# Altium schematic sheet 05_Outputs_LEDs.SchDoc(05_Outputs_LEDs)
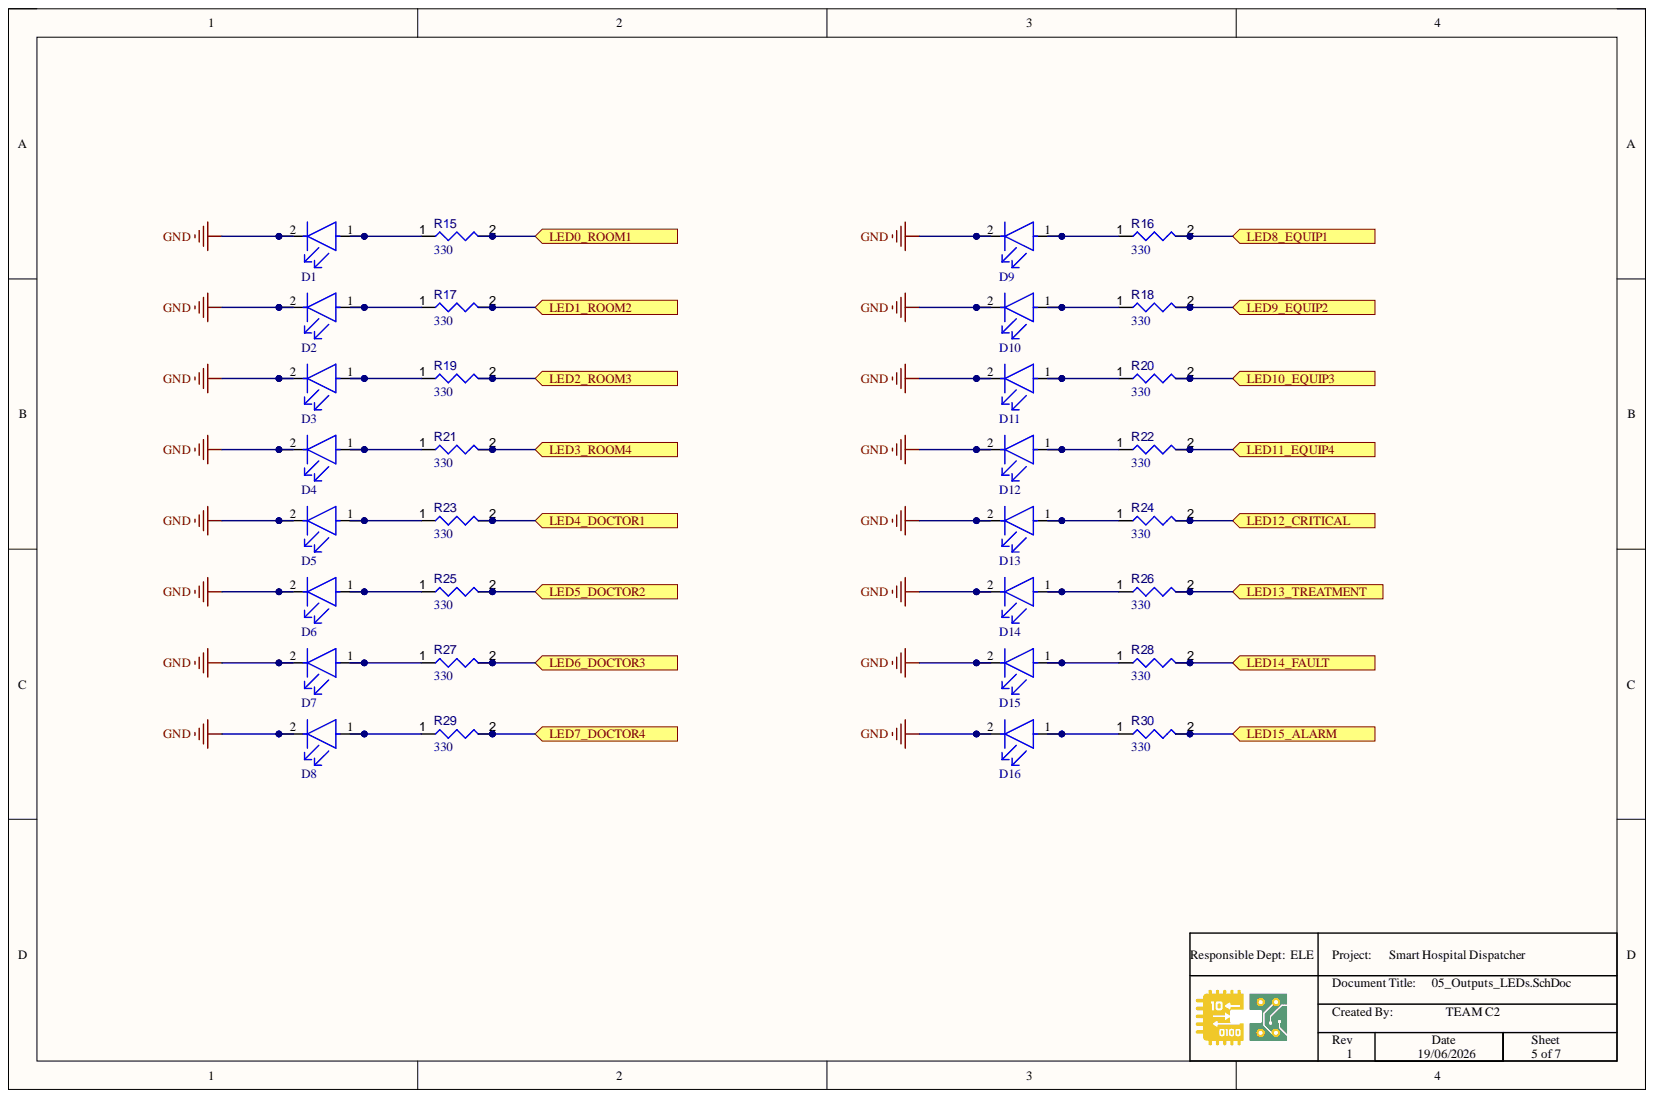
[diagram p0: 05_Outputs_LEDs.SchDoc]

## Parts
D1  SM0402GC  [FP-SM0402GC-MFG]  (value not drawn)
D2  SM0402GC  [FP-SM0402GC-MFG]  (value not drawn)
D3  SM0402GC  [FP-SM0402GC-MFG]  (value not drawn)
D4  SM0402GC  [FP-SM0402GC-MFG]  (value not drawn)
D5  SM0402GC  [FP-SM0402GC-MFG]  (value not drawn)
D6  SM0402GC  [FP-SM0402GC-MFG]  (value not drawn)
D7  SM0402GC  [FP-SM0402GC-MFG]  (value not drawn)
D8  SM0402GC  [FP-SM0402GC-MFG]  (value not drawn)
D9  SM0402GC  [FP-SM0402GC-MFG]  (value not drawn)
D10  SM0402GC  [FP-SM0402GC-MFG]  (value not drawn)
D11  SM0402GC  [FP-SM0402GC-MFG]  (value not drawn)
D12  SM0402GC  [FP-SM0402GC-MFG]  (value not drawn)
D13  SM0402GC  [FP-SM0402GC-MFG]  (value not drawn)
D14  SM0402GC  [FP-SM0402GC-MFG]  (value not drawn)
D15  SM0402GC  [FP-SM0402GC-MFG]  (value not drawn)
D16  SM0402GC  [FP-SM0402GC-MFG]  (value not drawn)
R15  value 330  [RESC0402(1005)_L]  (hidden comment: 330R2J)
R16  value 330  [RESC0402(1005)_L]  (hidden comment: 330R2J)
R17  value 330  [RESC0402(1005)_L]  (hidden comment: 330R2J)
R18  value 330  [RESC0402(1005)_L]  (hidden comment: 330R2J)
R19  value 330  [RESC0402(1005)_L]  (hidden comment: 330R2J)
R20  value 330  [RESC0402(1005)_L]  (hidden comment: 330R2J)
R21  value 330  [RESC0402(1005)_L]  (hidden comment: 330R2J)
R22  value 330  [RESC0402(1005)_L]  (hidden comment: 330R2J)
R23  value 330  [RESC0402(1005)_L]  (hidden comment: 330R2J)
R24  value 330  [RESC0402(1005)_L]  (hidden comment: 330R2J)
R25  value 330  [RESC0402(1005)_L]  (hidden comment: 330R2J)
R26  value 330  [RESC0402(1005)_L]  (hidden comment: 330R2J)
R27  value 330  [RESC0402(1005)_L]  (hidden comment: 330R2J)
R28  value 330  [RESC0402(1005)_L]  (hidden comment: 330R2J)
R29  value 330  [RESC0402(1005)_L]  (hidden comment: 330R2J)
R30  value 330  [RESC0402(1005)_L]  (hidden comment: 330R2J)

## Ports
LED0_ROOM1, LED1_ROOM2, LED2_ROOM3, LED3_ROOM4, LED4_DOCTOR1, LED5_DOCTOR2, LED6_DOCTOR3, LED7_DOCTOR4, LED8_EQUIP1, LED9_EQUIP2, LED10_EQUIP3, LED11_EQUIP4, LED12_CRITICAL, LED13_TREATMENT, LED14_FAULT, LED15_ALARM

## Nets
GND: D1.2 D2.2 D3.2 D4.2 D5.2 D6.2 D7.2 D8.2 D9.2 D10.2 D11.2 D12.2 D13.2 D14.2 D15.2 D16.2
LED0_ROOM1: R15.2 ; port LED0_ROOM1
LED1_ROOM2: R17.2 ; port LED1_ROOM2
LED2_ROOM3: R19.2 ; port LED2_ROOM3
LED3_ROOM4: R21.2 ; port LED3_ROOM4
LED4_DOCTOR1: R23.2 ; port LED4_DOCTOR1
LED5_DOCTOR2: R25.2 ; port LED5_DOCTOR2
LED6_DOCTOR3: R27.2 ; port LED6_DOCTOR3
LED7_DOCTOR4: R29.2 ; port LED7_DOCTOR4
LED8_EQUIP1: R16.2 ; port LED8_EQUIP1
LED9_EQUIP2: R18.2 ; port LED9_EQUIP2
LED10_EQUIP3: R20.2 ; port LED10_EQUIP3
LED11_EQUIP4: R22.2 ; port LED11_EQUIP4
LED12_CRITICAL: R24.2 ; port LED12_CRITICAL
LED13_TREATMENT: R26.2 ; port LED13_TREATMENT
LED14_FAULT: R28.2 ; port LED14_FAULT
LED15_ALARM: R30.2 ; port LED15_ALARM
NetD1_1: D1.1 R15.1
NetD2_1: D2.1 R17.1
NetD3_1: D3.1 R19.1
NetD4_1: D4.1 R21.1
NetD5_1: D5.1 R23.1
NetD6_1: D6.1 R25.1
NetD7_1: D7.1 R27.1
NetD8_1: D8.1 R29.1
NetD9_1: D9.1 R16.1
NetD10_1: D10.1 R18.1
NetD11_1: D11.1 R20.1
NetD12_1: D12.1 R22.1
NetD13_1: D13.1 R24.1
NetD14_1: D14.1 R26.1
NetD15_1: D15.1 R28.1
NetD16_1: D16.1 R30.1

## Library data of the parts
D1-D16 = CMP-35283-000001-2: LED GREEN CLEAR 0402 SMD; Dominant Wavelength: 572nm; Forward Voltage: 1.9V; Lens Color: Colorless; Lens Size: 1mm; Lens Style: Flat; Luminous Intensity: 28.5mcd; Test Current: 20mA; Viewing Angle: 130°; Case Code (Imperial): 0402; Case Code (Metric): 1005; Case/Package: 0402; Color: Green; Component Kind: Standard; Height: 0.71mm; Length: 1mm; Lens Transparency: Clear; Library Name: Altium Content Vault; Mount: Surface Mount; Packaging: Tape and Reel; Width: 0.5mm
R15-R30 = CMP-009-00095-2: 330R 0.063W 5% 0402 (1005 Metric)  SMD; Power: 63mW; Case/Package: 0402; Component Kind: Standard; Mounting Technology: SMT; Pins: 2; Tolerance: 5%; Library Name: BERTRAND MUNGU CHO
